# Altium schematic sheet CPLD.SchDoc(CPLD)
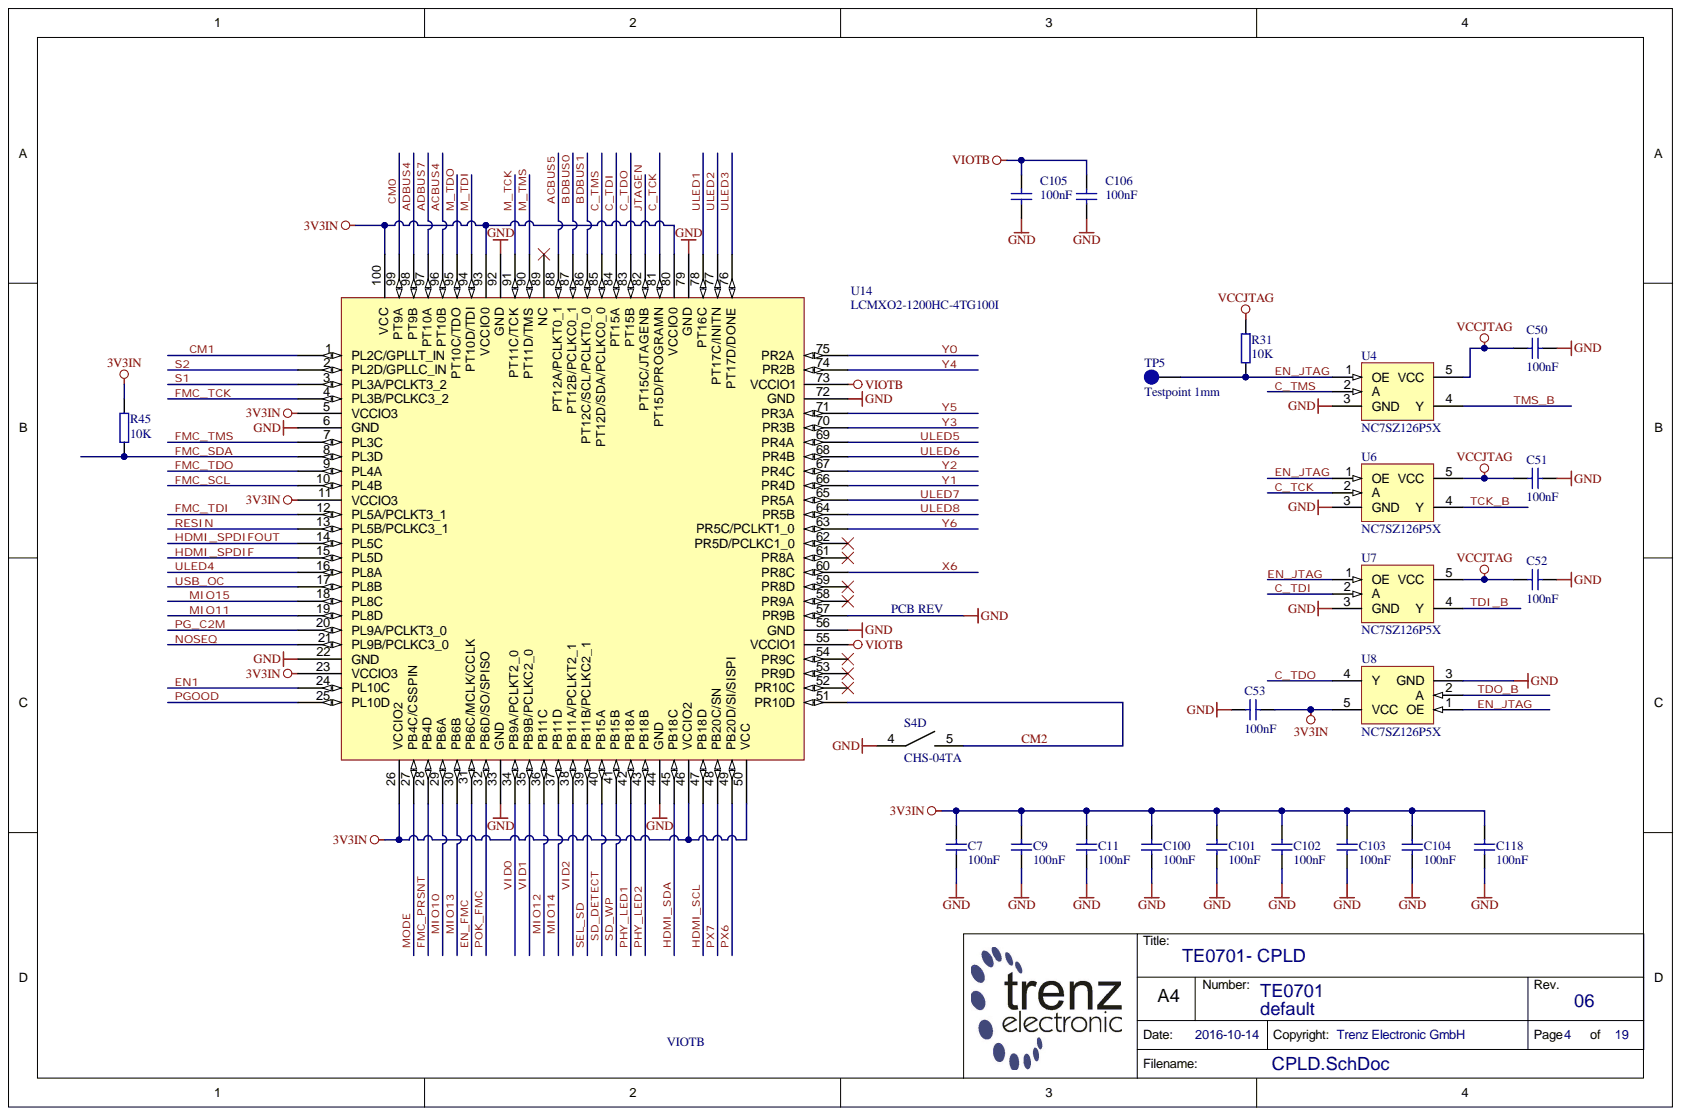
[diagram p0: CPLD.SchDoc]

## Parts
C7  100nF  [CAPC1005X55N]
C9  100nF  [CAPC1005X55N]
C11  100nF  [CAPC1005X55N]
C50  100nF  [CAPC1005X55N]
C51  100nF  [CAPC1005X55N]
C52  100nF  [CAPC1005X55N]
C53  100nF  [CAPC1005X55N]
C100  100nF  [CAPC1005X55N]
C101  100nF  [CAPC1005X55N]
C102  100nF  [CAPC1005X55N]
C103  100nF  [CAPC1005X55N]
C104  100nF  [CAPC1005X55N]
C105  100nF  [CAPC1005X55N]
C106  100nF  [CAPC1005X55N]
C118  100nF  [CAPC1005X55N]
R31  10K  [RESC1005X40N]
R45  10K  [RESC1005X40N]
S4  CHS-04TA  [CHS-04TA]  (part D)
TP5  Testpoint 1mm  [TP_1mm]
U4  NC7SZ126P5X  [SOT65P210X110-5N]
U6  NC7SZ126P5X  [SOT65P210X110-5N]
U7  NC7SZ126P5X  [SOT65P210X110-5N]
U8  NC7SZ126P5X  [SOT65P210X110-5N]
U14  LCMXO2-1200HC-4TG100I  [QFP50P1600X1600X160-100N]

## Nets
3V3IN: C7.1 C9.1 C11.1 C53.2 C100.1 C101.1 C102.1 C103.1 C104.1 C118.1 R45.1 U8.5(VCC) U14.5(VCCIO3) U14.11(VCCIO3) U14.23(VCCIO3) U14.26(VCCIO2) U14.46(VCCIO2) U14.50(VCC) U14.80(VCCIO0) U14.93(VCCIO0) U14.100(VCC)
GND: C7.2 C9.2 C11.2 C50.2 C51.1 C52.1 C53.1 C100.2 C101.2 C102.2 C103.2 C104.2 C105.2 C106.2 C118.2 S4.4 U4.3(GND) U6.3(GND) U7.3(GND) U8.3(GND) U14.6(GND) U14.22(GND) U14.33(GND) U14.44(GND) U14.56(GND) U14.57(PR9B) U14.72(GND) U14.79(GND) U14.92(GND)
VCCJTAG: C50.1 C51.2 C52.2 R31.1 U4.5(VCC) U6.5(VCC) U7.5(VCC)
VIOTB: C105.1 C106.1 U14.55(VCCIO1) U14.73(VCCIO1)
ACBUS4: U14.96(PT10B)
ACBUS5: U14.88(PT12A/PCLKT0_1)
ADBUS4: U14.98(PT9B)
ADBUS7: U14.97(PT10A)
BDBUS0: U14.87(PT12B/PCLKC0_1)
BDBUS1: U14.86(PT12C/SCL/PCLKT0_0)
C_TCK: U6.2(A) U14.81(PT15D/PROGRAMN)
C_TDI: U7.2(A) U14.84(PT15A)
C_TDO: U8.4(Y) U14.83(PT15B)
C_TMS: U4.2(A) U14.85(PT12D/SDA/PCLKC0_0)
CM0: U14.99(PT9A)
CM1: U14.1(PL2C/GPLLT_IN)
CM2: S4.5 U14.51(PR10D)
EN1: U14.24(PL10C)
EN_FMC: U14.31(PB6C/MCLK/CCLK)
EN_JTAG: R31.2 TP5.1 U4.1(OE) U6.1(OE) U7.1(OE) U8.1(OE)
FMC_PRSNT: U14.28(PB4D)
FMC_SCL: U14.10(PL4B)
FMC_SDA: R45.2 U14.8(PL3D)
FMC_TCK: U14.4(PL3B/PCLKC3_2)
FMC_TDI: U14.12(PL5A/PCLKT3_1)
FMC_TDO: U14.9(PL4A)
FMC_TMS: U14.7(PL3C)
HDMI_SCL: U14.47(PB18D)
HDMI_SDA: U14.45(PB18C)
HDMI_SPDIF: U14.15(PL5D)
HDMI_SPDIFOUT: U14.14(PL5C)
JTAGEN: U14.82(PT15C/JTAGENB)
M_TCK: U14.91(PT11C/TCK)
M_TDI: U14.94(PT10D/TDI)
M_TDO: U14.95(PT10C/TDO)
M_TMS: U14.90(PT11D/TMS)
MIO10: U14.29(PB6A)
MIO11: U14.19(PL8D)
MIO12: U14.36(PB11C)
MIO13: U14.30(PB6B)
MIO14: U14.37(PB11D)
MIO15: U14.18(PL8C)
MODE: U14.27(PB4C/CSSPIN)
NOSEQ: U14.21(PL9B/PCLKC3_0)
PG_C2M: U14.20(PL9A/PCLKT3_0)
PGOOD: U14.25(PL10D)
PHY_LED1: U14.42(PB18A)
PHY_LED2: U14.43(PB18B)
POK_FMC: U14.32(PB6D/SO/SPISO)
PX6: U14.49(PB20D/SI/SISPI)
PX7: U14.48(PB20C/SN)
RESIN: U14.13(PL5B/PCLKC3_1)
S1: U14.3(PL3A/PCLKT3_2)
S2: U14.2(PL2D/GPLLC_IN)
SD_DETECT: U14.40(PB15A)
SD_WP: U14.41(PB15B)
SEL_SD: U14.39(PB11B/PCLKC2_1)
TCK_B: U6.4(Y)
TDI_B: U7.4(Y)
TDO_B: U8.2(A)
TMS_B: U4.4(Y)
ULED1: U14.78(PT16C)
ULED2: U14.77(PT17C/INITN)
ULED3: U14.76(PT17D/DONE)
ULED4: U14.16(PL8A)
ULED5: U14.69(PR4A)
ULED6: U14.68(PR4B)
ULED7: U14.65(PR5A)
ULED8: U14.64(PR5B)
USB_OC: U14.17(PL8B)
VID0: U14.34(PB9A/PCLKT2_0)
VID1: U14.35(PB9B/PCLKC2_0)
VID2: U14.38(PB11A/PCLKT2_1)
X6: U14.60(PR8C)
Y0: U14.75(PR2A)
Y1: U14.66(PR4D)
Y2: U14.67(PR4C)
Y3: U14.70(PR3B)
Y4: U14.74(PR2B)
Y5: U14.71(PR3A)
Y6: U14.63(PR5C/PCLKT1_0)

Not connected: U14 52(PR10C) 53(PR9D) 54(PR9C) 58(PR9A) 59(PR8D) 61(PR8A) 62(PR5D/PCLKC1_0) 89(NC)

## Library data of the parts
C7 C9 C11 C50-C53 C100-C106 C118 = 22472: KON 100nF 25V X5R SMD; Database Table Name: C_1005_0402; Mixed: SMT; Preferred: Yes; Value: 100n; AN: 22472; Case: 1005 (0402); Component Kind: Standard; Library Name: TE.DbLib; Technology: X7R; Voltage: 25V
R31 R45 = 22750: WID SMD 10K 1% 0,063W; Database Table Name: R_1005_0402; Mixed: SMT; Preferred: Yes; AN: 22750; Case: 1005 (0402); Component Kind: Standard; Library Name: TE.DbLib; Technology: TK100; Tolerance: 1%; Value: 10K
S4 = 23417: DIP Schalter 4 fach 1,27mm SMD; Database Table Name: PB_SW; Mixed: SMT; Preferred: Yes; AN: 23417; Component Kind: Standard; H-ART: CHS-04TA; Library Name: TE.DbLib; Trenz-Stock: Yes; HelpURL: B:\AD\adlib\datasheet\CHS
TP5 = 25063: Database Table Name: PCB; Mixed: SMT; AN: 25063; Component Kind: Standard; Description: Testpoint 1mm; Library Name: TE.DbLib
U4 U6-U8 = 24506: Database Table Name: LOGIC; Mixed: SMT; Preferred: Yes; AN: 24506; Case: SC70-5; Component Kind: Standard; Description: NC7SZ126P5X; H-ART: NC7SZ126P5X; Library Name: TE.DbLib; Trenz-Stock: Yes; HelpURL: B:\AD\adlib\datasheet\NC7SZ126
U14 = 25180: Database Table Name: PROG_LOGIC; Mixed: SMT; Trenz-Stock: Yes; AN: 25180; Case: TQFP100; Component Kind: Standard; Description: LCMXO2-1200HC-4TG100I; H-ART: LCMXO2-1200HC-4TG100I; Library Name: TE.DbLib; HelpURL: B:\AD\adlib\datasheet\MachXO2
